# Altium schematic sheet relays.SchDoc(relays)
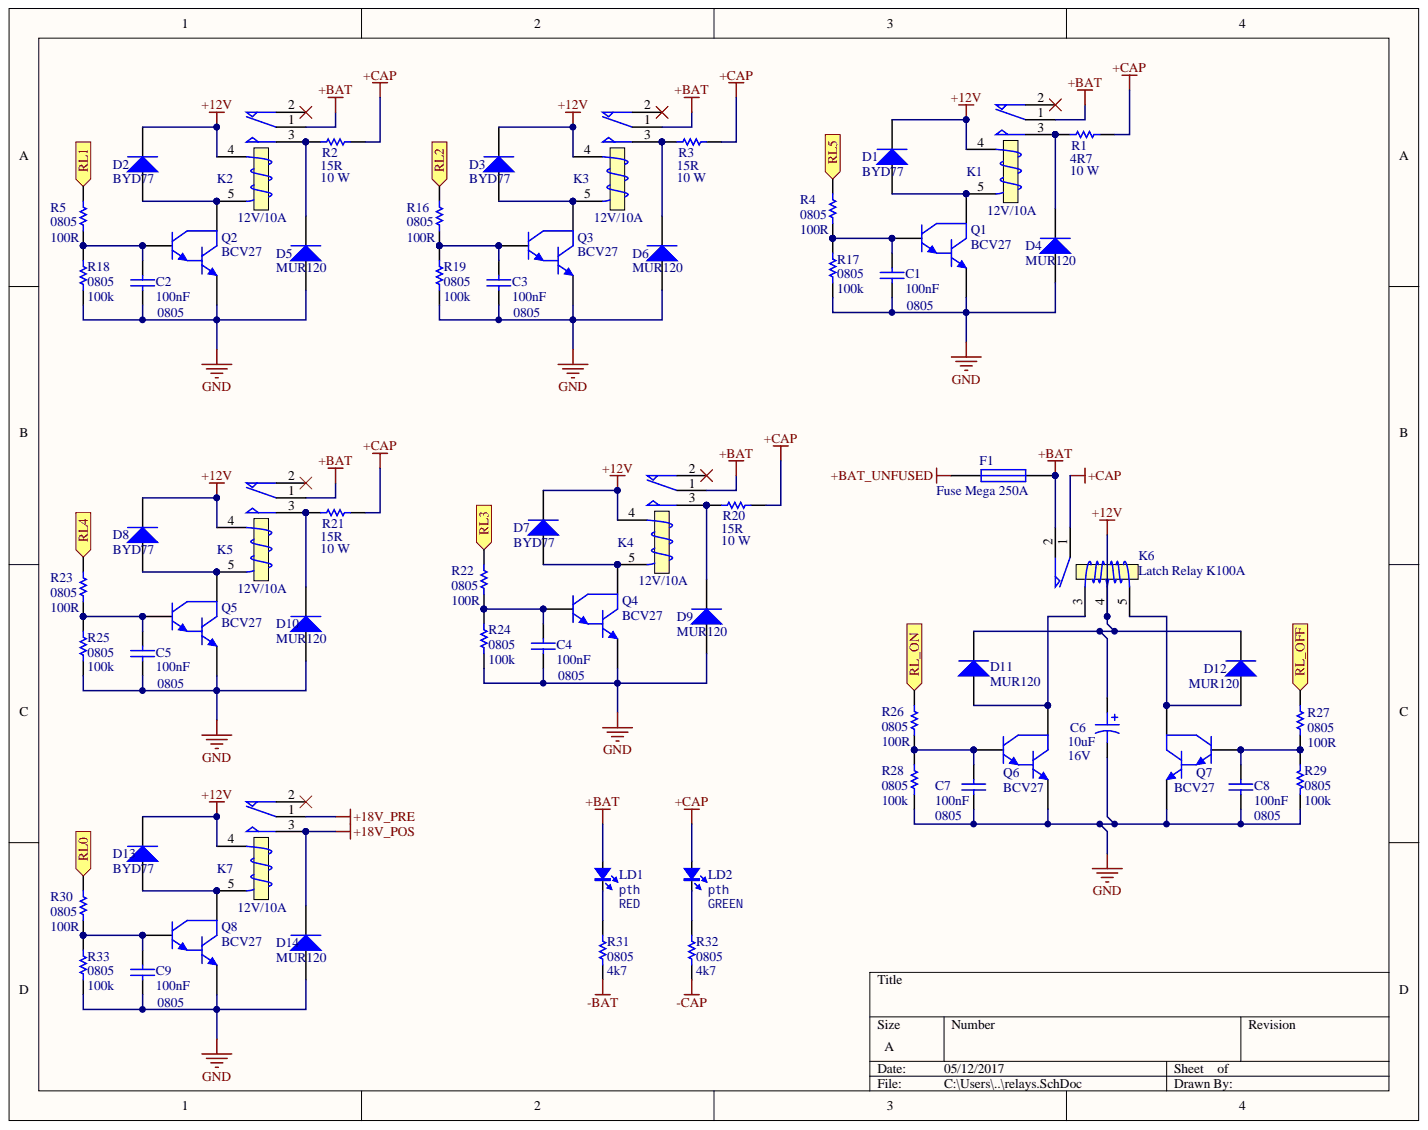
[diagram p0: relays.SchDoc]

## Parts
C1  100nF  [0805]
C2  100nF  [0805]
C3  100nF  [0805]
C4  100nF  [0805]
C5  100nF  [0805]
C6  10uF  Voltage: 16V  [CP3.5mm-8.0mm]
C7  100nF  [0805]
C8  100nF  [0805]
C9  100nF  [0805]
D1  BYD77  [DIO10.2-7x2.7]
D2  BYD77  [DIO10.2-7x2.7]
D3  BYD77  [DIO10.2-7x2.7]
D4  MUR120  [DSO-C2/X2.3]
D5  MUR120  [DSO-C2/X2.3]
D6  MUR120  [DSO-C2/X2.3]
D7  BYD77  [DIO10.2-7x2.7]
D8  BYD77  [DIO10.2-7x2.7]
D9  MUR120  [DSO-C2/X2.3]
D10  MUR120  [DSO-C2/X2.3]
D11  MUR120  [DIO10.2-7x2.7]
D12  MUR120  [DIO10.2-7x2.7]
D13  BYD77  [DIO10.2-7x2.7]
D14  MUR120  [DSO-C2/X2.3]
F1  Fuse Mega 250A  value 250A  [FuseANL]
K1  12V/10A  [RELE]
K2  12V/10A  [RELE]
K3  12V/10A  [RELE]
K4  12V/10A  [RELE]
K5  12V/10A  [RELE]
K6  Latch Relay K100A  [K100A]
K7  12V/10A  [RELE]
LD1  RED  Type: pth  [LED3MM]
LD2  GREEN  Type: pth  [LED3MM]
Q1  BCV27  [SOT95P240-3N]
Q2  BCV27  [SOT95P240-3N]
Q3  BCV27  [SOT95P240-3N]
Q4  BCV27  [SOT95P240-3N]
Q5  BCV27  [SOT95P240-3N]
Q6  BCV27  [SOT95P240-3N]
Q7  BCV27  [SOT95P240-3N]
Q8  BCV27  [SOT95P240-3N]
R1  4R7  [R-AC10]
R2  15R  [R-AC10]
R3  15R  [R-AC10]
R4  0805  value 100R  [0805R]
R5  0805  value 100R  [0805R]
R16  0805  value 100R  [0805R]
R17  0805  value 100k  [0805R]
R18  0805  value 100k  [0805R]
R19  0805  value 100k  [0805R]
R20  15R  [R-AC10]
R21  15R  [R-AC10]
R22  0805  value 100R  [0805R]
R23  0805  value 100R  [0805R]
R24  0805  value 100k  [0805R]
R25  0805  value 100k  [0805R]
R26  0805  value 100R  [0805R]
R27  0805  value 100R  [0805R]
R28  0805  value 100k  [0805R]
R29  0805  value 100k  [0805R]
R30  0805  value 100R  [0805R]
R31  0805  value 4k7  [0805R]
R32  0805  value 4k7  [0805R]
R33  0805  value 100k  [0805R]

## Ports
RL0, RL1, RL2, RL3, RL4, RL5, RL_OFF, RL_ON

## Nets
+12V: C6.1 D1.2 D2.2 D3.2 D7.2 D8.2 D11.2 D12.2 D13.2 K1.4 K2.4 K3.4 K4.4 K5.4 K6.4 K7.4
+18V_POS: D14.2 K7.3
+18V_PRE: K7.1
+BAT: F1.2 K1.1 K2.1 K3.1 K4.1 K5.1 K6.2 LD1.1
+BAT_UNFUSED: F1.1
+CAP: K6.1 LD2.1 R1.1 R2.1 R3.1 R20.1 R21.1
-BAT: R31.1
-CAP: R32.1
GND: C1.1 C2.1 C3.1 C4.1 C5.1 C6.2 C7.1 C8.1 C9.1 D4.1 D5.1 D6.1 D9.1 D10.1 D14.1 Q1.3 Q2.3 Q3.3 Q4.3 Q5.3 Q6.3 Q7.3 Q8.3 R17.1 R18.1 R19.1 R24.1 R25.1 R28.1 R29.1 R33.1
RL0: R30.2 ; port RL0
RL1: R5.2 ; port RL1
RL2: R16.2 ; port RL2
RL3: R22.2 ; port RL3
RL4: R23.2 ; port RL4
RL5: R4.2 ; port RL5
RL_OFF: R27.2 ; port RL_OFF
RL_ON: R26.2 ; port RL_ON
NetC1_2: C1.2 Q1.2 R4.1 R17.2
NetC2_2: C2.2 Q2.2 R5.1 R18.2
NetC3_2: C3.2 Q3.2 R16.1 R19.2
NetC4_2: C4.2 Q4.2 R22.1 R24.2
NetC5_2: C5.2 Q5.2 R23.1 R25.2
NetC7_2: C7.2 Q6.2 R26.1 R28.2
NetC8_2: C8.2 Q7.2 R27.1 R29.2
NetC9_2: C9.2 Q8.2 R30.1 R33.2
NetD1_1: D1.1 K1.5 Q1.1
NetD2_1: D2.1 K2.5 Q2.1
NetD3_1: D3.1 K3.5 Q3.1
NetD4_2: D4.2 K1.3 R1.2
NetD5_2: D5.2 K2.3 R2.2
NetD6_2: D6.2 K3.3 R3.2
NetD7_1: D7.1 K4.5 Q4.1
NetD8_1: D8.1 K5.5 Q5.1
NetD9_2: D9.2 K4.3 R20.2
NetD10_2: D10.2 K5.3 R21.2
NetD11_1: D11.1 K6.3 Q6.1
NetD12_1: D12.1 K6.5 Q7.1
NetD13_1: D13.1 K7.5 Q8.1
NetLD1_2: LD1.2 R31.2
NetLD2_2: LD2.2 R32.2

Not connected: K1 2; K2 2; K3 2; K4 2; K5 2; K7 2

## Library data of the parts
C1-C5 C7-C9 = Cap: Capacitor; Type: pth; Voltage: 25V; Component Kind: Standard; Library Name: Miscellaneous Devices.IntLib; Simulation: CAP; Value: 100nF
C6 = CapacitorPol: Solid Aluminum Capacitor with Organic Semiconductor Electrolyte: 100uF, 10V, Bulk Pack; Type: pth; Component Kind: Standard; Simulation: CAP; Value: 10uF; Library Name: R2R - Nova.intlib
D1-D14 = Diode: Default Diode; Type: pth; Component Kind: Standard; Library Name: Miscellaneous Devices.IntLib; Simulation: DIODE
  D1-D3 D7 D8 D13: Value: BYD77
  D4-D6 D9-D12 D14: Value: MUR120
F1 = Fuse 1: Fuse; Type: MEGA / ANL; Component Kind: Standard; Library Name: Miscellaneous Devices.IntLib; Simulation: FUSE
K1-K7 = Relay: SPDT Relay; Value: KRE 3; Component Kind: Standard; Simulation: SPDTRELAY; Type: KRE
  K1-K5 K7: Library Name: Miscellaneous Devices.IntLib
  K6: Library Name: Zenite_Symbols.SchLib
LD1 LD2 = LED_0805: LED; Component Kind: Standard; Library Name: SCH_Active.SchLib
  LD1: Value: RED
  LD2: Value: GREEN
Q1-Q8 = NPN1: NPN Darlington Bipolar Transistor; Component Kind: Standard; Library Name: Miscellaneous Devices.IntLib; Simulation: NPN1; Value: BCV27
R1-R3 R20 R21 = ResistorPower: Power Resistor; Power: 10 W; Tolerance: 5%; Value: 15R 10W; Component Kind: Standard; Type: pth; Library Name: R2R - Nova.intlib
R4 R5 R16-R19 R22-R33 = Res1: Resistor; Component Kind: Standard; Library Name: Miscellaneous Devices.IntLib; Simulation: RESISTOR; Type: 0805
